# Altium schematic sheet usb2ppm.SchDoc
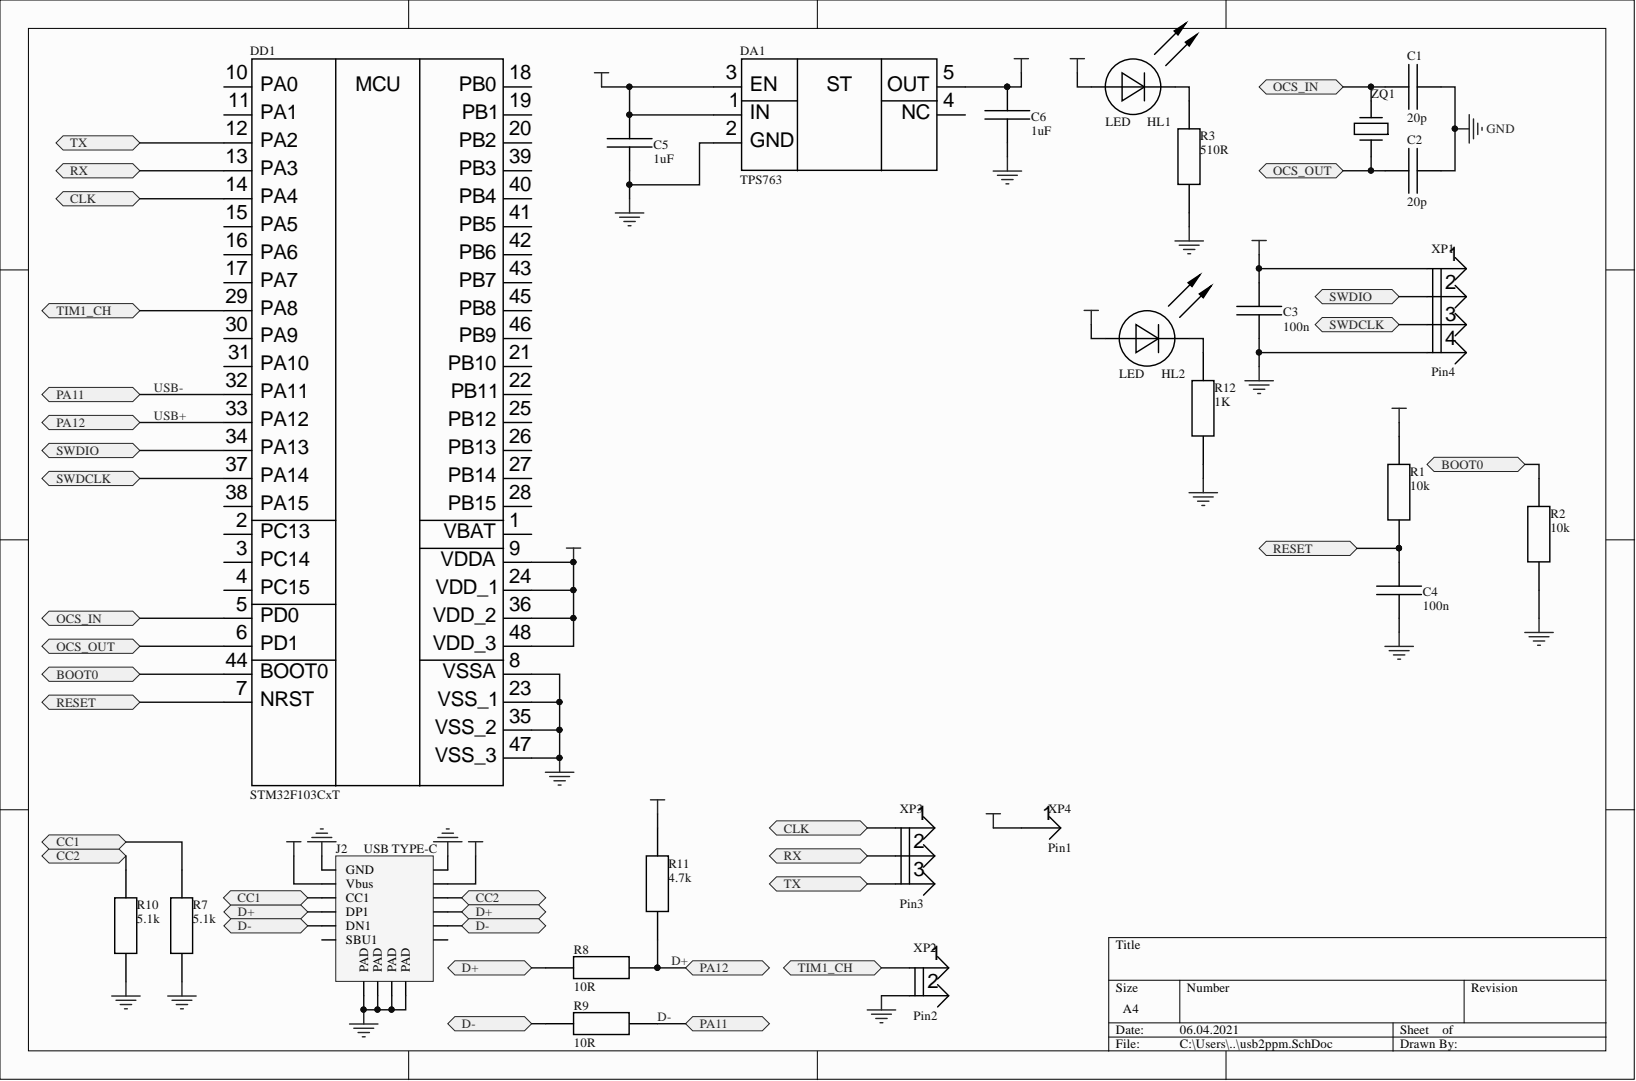
[diagram p0: usb2ppm.SchDoc]

## Parts
C1  20p  [CAP_0603]
C2  20p  [CAP_0603]
C3  100n  [CAP_0603]
C4  100n  [CAP_0603]
C5  1uF  [CAP_0603]
C6  1uF  [CAP_0603]
DA1  TPS763  [SOT23-5]
DD1  STM32F103CxT  [LQFP48]
HL1  LED  [LED_0603_R]
HL2  LED  [LED_0603_R]
J2  USB TYPE-C  [USB TYPE-C]
R1  10k  [RES_0603]
R2  10k  [RES_0603]
R3  510R  [RES_0603]
R7  5.1k  [RES_0603]
R8  10R  [RES_0603]
R9  10R  [RES_0603]
R10  5.1k  [RES_0603]
R11  4.7k  [RES_0603]
R12  1K  [RES_0603]
XP1  Pin4  [PLS_4]
XP2  Pin2  [PLS_2]
XP3  Pin3  [PLS_3]
XP4  Pin1  [PLS_1]
ZQ1  Quartz  [CRYS_3225]  (value not drawn)

## Ports
BOOT0, CC1, CC2, CLK, D+, D-, OCS_IN, OCS_OUT, PA11, PA12, RESET, RX, SWDCLK, SWDIO, TIM1_CH, TX

## Nets
+3.3V: C3.2 C6.2 DA1.5(OUT) DD1.9(VDDA) DD1.24(VDD_1) DD1.36(VDD_2) DD1.48(VDD_3) HL1.A R1.2 R11.2 XP1.1
+5V: C5.2 DA1.1(IN) DA1.3(EN) HL2.A J2.A4B9(Vbus) J2.B4A9 XP4.1
GND: C1.2 C2.2 C3.1 C4.1 C5.1 C6.1 DA1.2(GND) DD1.8(VSSA) DD1.23(VSS_1) DD1.35(VSS_2) DD1.47(VSS_3) J2.A1B12(GND) J2.B1A12 J2.S1(PAD) J2.S2(PAD) J2.S3(PAD) J2.S4(PAD) R2.1 R3.1 R7.1 R10.1 R12.1 XP1.4 XP2.2 ZQ1.2 ZQ1.4
BOOT0: DD1.44(BOOT0) R2.2 ; port BOOT0
CC1: J2.A5(CC1) R7.2 ; port CC1
CC2: J2.B5 R10.2 ; port CC2
CLK: DD1.14(PA4) XP3.1 ; port CLK
D+: DD1.33(PA12) R8.2 R11.1 ; port PA12
D-: DD1.32(PA11) R9.2 ; port PA11
OCS_IN: C1.1 DD1.5(PD0) ZQ1.1 ; port OCS_IN
OCS_OUT: C2.1 DD1.6(PD1) ZQ1.3 ; port OCS_OUT
RESET: C4.2 DD1.7(NRST) R1.1 ; port RESET
RX: DD1.13(PA3) XP3.2 ; port RX
SWDCLK: DD1.37(PA14) XP1.3 ; port SWDCLK
SWDIO: DD1.34(PA13) XP1.2 ; port SWDIO
TIM1_CH: DD1.29(PA8) XP2.1 ; port TIM1_CH
TX: DD1.12(PA2) XP3.3 ; port TX
NetHL1_C: HL1.C R3.2
NetHL2_C: HL2.C R12.2
NetJ2_A6: J2.A6(DP1) J2.B6 R8.1
NetJ2_A7: J2.A7(DN1) J2.B7 R9.1

Not connected: DA1 4(NC); DD1 1(VBAT) 2(PC13) 3(PC14) 4(PC15) 10(PA0) 11(PA1) 15(PA5) 16(PA6) 17(PA7) 18(PB0) 19(PB1) 20(PB2) 21(PB10) 22(PB11) 25(PB12) 26(PB13) 27(PB14) 28(PB15) 30(PA9) 31(PA10) 38(PA15) 39(PB3) 40(PB4) 41(PB5) 42(PB6) 43(PB7) 45(PB8) 46(PB9); J2 A8(SBU1) B8

## Library data of the parts
C1-C6 = Capacitor: Value: .COMMENT; Component Kind: Standard; Description: Capacitor; Library Name: MyLib.SchLib; Simulation: Capacitor
DA1 = TPS763: Low-Dropout Linear Regulator; Component Kind: Standard; Library Name: MyLib.SchLib
DD1 = STM32F103CxT: ARM MCU; Component Kind: Standard; Library Name: MyLib.SchLib
R1-R3 R7-R12 = Resistor: Value: .COMMENT; Component Kind: Standard; Description: Resistor; Library Name: MyLib.SchLib; Simulation: Resistor
XP1 = Pin4: 4 Pins; Component Kind: Standard; Library Name: MyLib.SchLib
XP2 = Pin2: 2 Pins; Component Kind: Standard; Library Name: MyLib.SchLib
XP3 = Pin3: 3 Pins; Component Kind: Standard; Library Name: MyLib.SchLib
XP4 = Pin1: 1 Pin; Component Kind: Standard; Library Name: MyLib.SchLib
ZQ1 = Quartz: freq: 8; Component Kind: Standard; Description: Quartz; Library Name: MyLib.SchLib
HL1 HL2 = LED: Component Kind: Standard; Description: LED; Library Name: MyLib.SchLib
J2 = USB TYPE-C: Component Kind: Standard; Library Name: MyLib.SchLib
